# Altium schematic sheet WiFi+BT.SchDoc
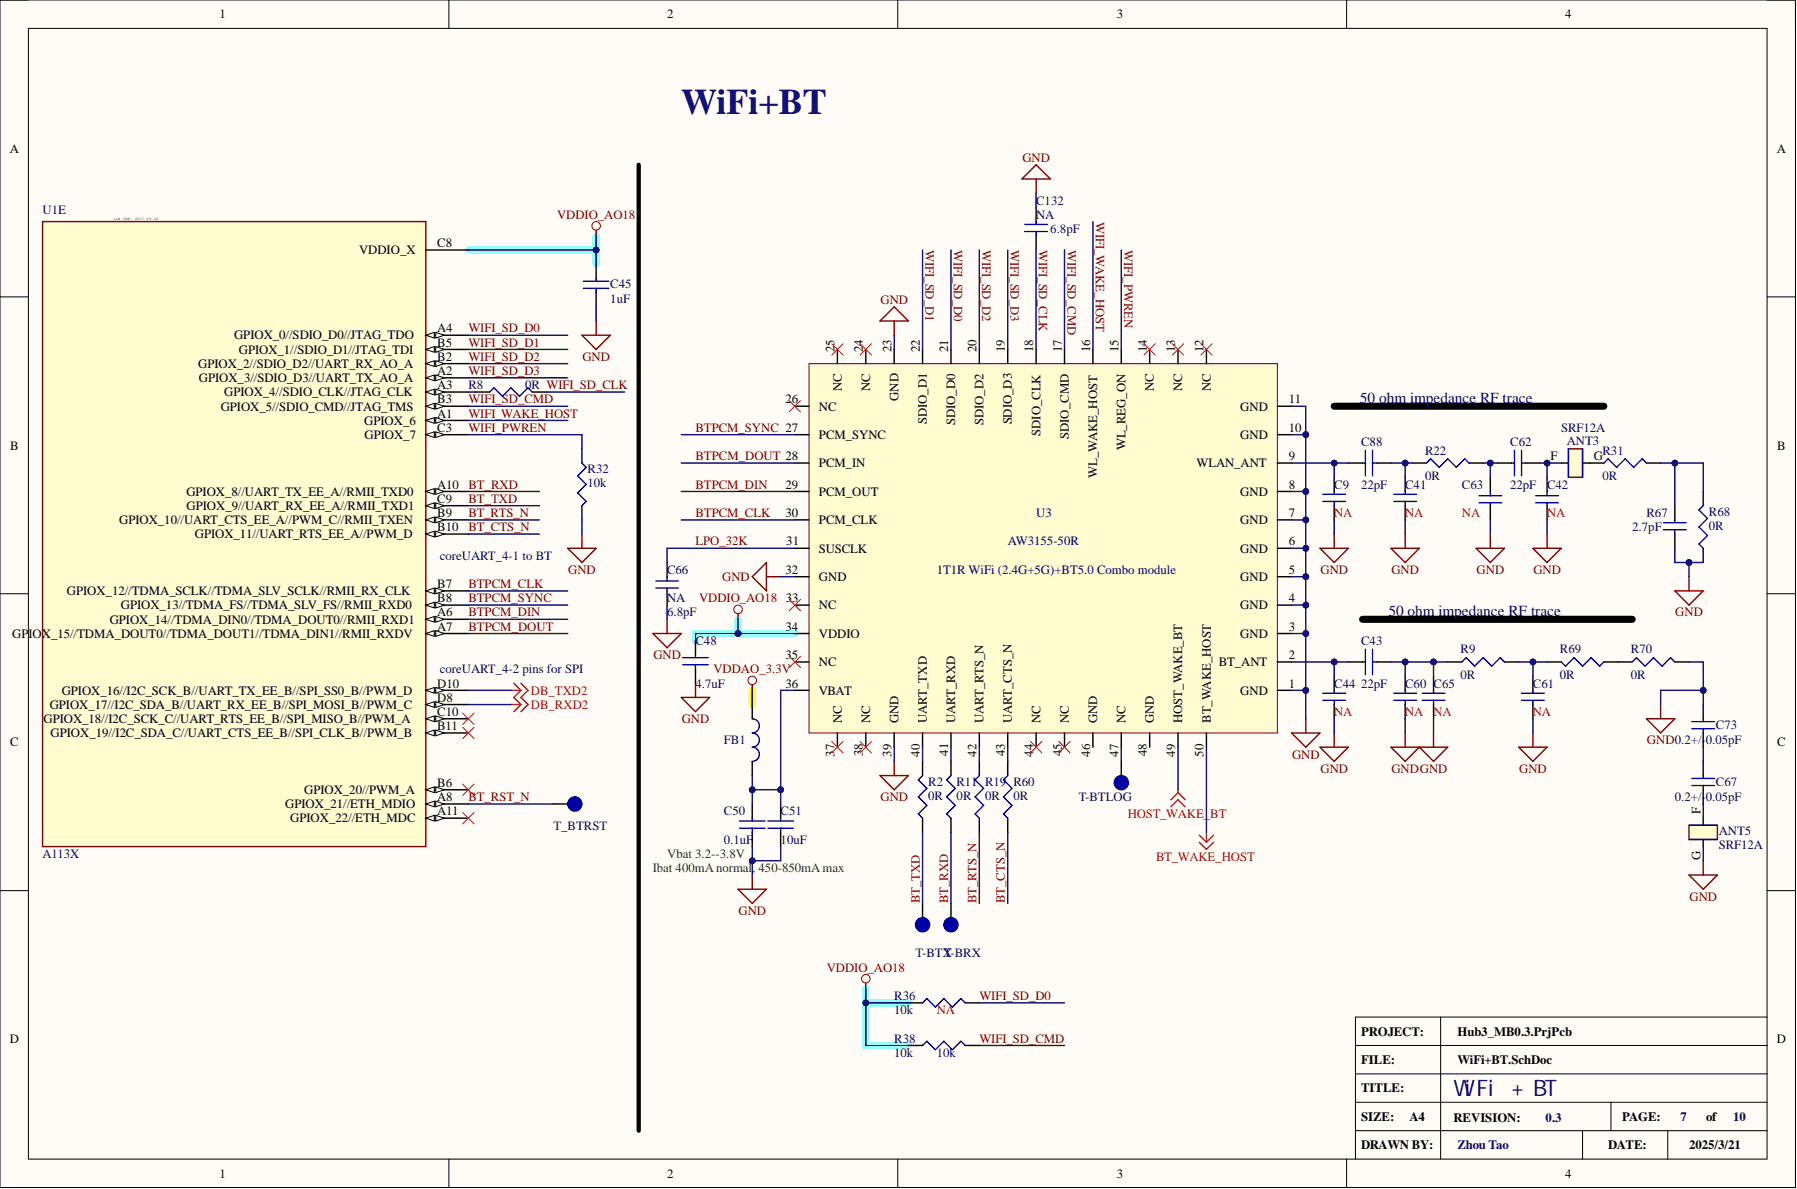
[diagram p0: WiFi+BT.SchDoc]

## Parts
ANT3  SRF12A  [ANT1608]
ANT5  SRF12A  [ANT1608]
C9  NA  [C0402]  (no BOM)
C41  NA  [C0402]  (no BOM)
C42  NA  [C0402]  (no BOM)
C43  22pF  [C0402]
C44  NA  [C0402]  (no BOM)
C45  1uF  [C0201]
C48  4.7uF  [C0603]
C50  0.1uF  [C0201]
C51  10uF  [C0603]
C60  NA  [C0402]  (no BOM)
C61  NA  [C0402]  (no BOM)
C62  22pF  [C0402]
C63  NA  [C0402]  (no BOM)
C65  NA  [C0402]  (no BOM)
C66  NA  value 6.8pF  [C0201]  (no BOM)
C67  0.2+/-0.05pF  [C0402]
C73  0.2+/-0.05pF  [C0402]
C88  22pF  [C0402]
C132  NA  value 6.8pF  [C0201]  (no BOM)
FB1  MZAS1608G251－1R1TF  [L0603]  (value not drawn)
R2  0R  [R0201]
R8  0R  [R0201]
R9  0R  [R0402]
R11  0R  [R0201]
R19  0R  [R0201]
R22  0R  [R0402]
R31  0R  [R0402]
R32  value 10k  [R0402]  (hidden comment: RES_10k_0402_1%)
R36  NA  value 10k  [R0201]  (no BOM)
R38  10k  [R0201]
R60  0R  [R0201]
R67  2.7pF  [C0402]
R68  0R  [R0402]
R69  0R  [R0402]
R70  0R  [R0402]
T-BRX  T_POINT_R_1  [TP12]  (value not drawn)  (no BOM)
T-BTLOG  T_POINT_R_1  [TP12]  (value not drawn)  (no BOM)
T-BTX  T_POINT_R_1  [TP12]  (value not drawn)  (no BOM)
T_BTRST  (no value)  [TP_D0.6mm]  (no BOM)
U1  A113X  [A113X_284]  (part E)
U3  AW3155-50R  Des: 1T1R WiFi (2.4G+5G)+BT5.0 Combo module  [AW3155-50]

## Nets
BT_WAKE_HOST: U3.50(BT_WAKE_HOST)
DB_RXD2: U1.D8(GPIOX_17//I2C_SDA_B//UART_RX_EE_B//SPI_MOSI_B//PWM_C)
DB_TXD2: U1.D10(GPIOX_16//I2C_SCK_B//UART_TX_EE_B//SPI_SS0_B//PWM_D)
GND: ANT5.G C9.2 C41.2 C42.1 C44.2 C45.2 C48.2 C50.2 C51.2 C60.2 C61.2 C63.1 C65.2 C66.1 C73.1 C132.1 R32.2 R67.2 R68.1 R70.2 U3.1(GND) U3.3(GND) U3.4(GND) U3.5(GND) U3.6(GND) U3.7(GND) U3.8(GND) U3.10(GND) U3.11(GND) U3.23(GND) U3.32(GND) U3.39(GND)
HOST_WAKE_BT: U3.49(HOST_WAKE_BT)
VDDAO_3.3V: FB1.1
VDDIO_AO18: C45.1 C48.1 R36.1 R38.1 U1.C8(VDDIO_X) U3.34(VDDIO)
BT_CTS_N: R60.1 U1.B10(GPIOX_11//UART_RTS_EE_A//PWM_D)
BT_RST_N: T_BTRST.1 U1.A8(GPIOX_21//ETH_MDIO)
BT_RTS_N: R19.1 U1.B9(GPIOX_10//UART_CTS_EE_A//PWM_C//RMII_TXEN)
BT_RXD: R11.1 T-BRX.1 U1.A10(GPIOX_8//UART_TX_EE_A//RMII_TXD0)
BT_TXD: R2.1 T-BTX.1 U1.C9(GPIOX_9//UART_RX_EE_A//RMII_TXD1)
BTPCM_CLK: U1.B7(GPIOX_12//TDMA_SCLK//TDMA_SLV_SCLK//RMII_RX_CLK) U3.30(PCM_CLK)
BTPCM_DIN: U1.A6(GPIOX_14//TDMA_DIN0//TDMA_DOUT0//RMII_RXD1) U3.29(PCM_OUT)
BTPCM_DOUT: U1.A7 U3.28(PCM_IN)
BTPCM_SYNC: U1.B8(GPIOX_13//TDMA_FS//TDMA_SLV_FS//RMII_RXD0) U3.27(PCM_SYNC)
LPO_32K: C66.2 U3.31(SUSCLK)
WIFI_PWREN: R32.1 U1.C3(GPIOX_7) U3.15(WL_REG_ON)
WIFI_SD_CLK: C132.2 R8.2 U3.18(SDIO_CLK)
WIFI_SD_CMD: R38.2 U1.B3(GPIOX_5//SDIO_CMD//JTAG_TMS) U3.17(SDIO_CMD)
WIFI_SD_D0: R36.2 U1.A4(GPIOX_0//SDIO_D0//JTAG_TDO) U3.21(SDIO_D0)
WIFI_SD_D1: U1.B5(GPIOX_1//SDIO_D1//JTAG_TDI) U3.22(SDIO_D1)
WIFI_SD_D2: U1.B2(GPIOX_2//SDIO_D2//UART_RX_AO_A) U3.20(SDIO_D2)
WIFI_SD_D3: U1.A2(GPIOX_3//SDIO_D3//UART_TX_AO_A) U3.19(SDIO_D3)
WIFI_WAKE_HOST: U1.A1(GPIOX_6) U3.16(WL_WAKE_HOST)
NetANT3_F: ANT3.F C42.2 C62.2
NetANT3_G: ANT3.G R31.1
NetANT5_F: ANT5.F C67.2
NetC9_1: C9.1 C88.1 U3.9(WLAN_ANT)
NetC41_1: C41.1 C88.2 R22.1
NetC43_1: C43.1 C44.1 U3.2(BT_ANT)
NetC43_2: C43.2 C60.1 C65.1 R9.1
NetC50_1: C50.1 C51.1 FB1.2 U3.36(VBAT)
NetC61_1: C61.1 R9.2 R69.2
NetC62_1: C62.1 C63.2 R22.2
NetC67_1: C67.1 C73.2
NetR2_2: R2.2 U3.40(UART_TXD)
NetR8_1: R8.1 U1.A3(GPIOX_4//SDIO_CLK//JTAG_CLK)
NetR11_2: R11.2 U3.41(UART_RXD)
NetR19_2: R19.2 U3.42(UART_RTS_N)
NetR31_2: R31.2 R67.1 R68.2
NetR60_2: R60.2 U3.43(UART_CTS_N)
NetR69_1: R69.1 R70.1
NetT-BTLOG_1: T-BTLOG.1 U3.47(NC)

Not connected: U1 A11(GPIOX_22//ETH_MDC) B6(GPIOX_20//PWM_A) B11(GPIOX_19//I2C_SDA_C//UART_CTS_EE_B//SPI_CLK_B//PWM_B) C10(GPIOX_18//I2C_SCK_C//UART_RTS_EE_B//SPI_MISO_B//PWM_A); U3 12(NC) 13(NC) 14(NC) 24(NC) 25(NC) 26(NC) 33(NC) 35(NC) 37(NC) 38(NC) 44(NC) 45(NC) 46(GND) 48(GND)

## Library data of the parts
ANT3 ANT5 = ANT_SMD_1608_SRF12A: Manufacturer: HanYang; Des: SMD ANT, 1608 size; Component Kind: Standard; Part Number: SRF12A; value: SRF12A; Library Name: Lib_sch_spk_V1_2020604.SchLib
C9 C41 C42 C44 C60 C61 C63 C65 = Cap_0402_NA_RF: Manufacturer: Murata; Part Number: TBD; Des: TBD.....50V, C0G, NP0 0402; value: TBD; Library Name: Lib_sch_spk_V1_2020604.SchLib
C43 C62 C88 = Cap_22pF_0402_5%_25V: Manufacturer: Murata; Part Number: GRM1555C1E220JA01D; Des: 22pF,0402,25V,5%,NP0; Component Kind: Standard; value: 22pF; Library Name: Lib_sch_Hubv3_2332.SchLib
C45 = Cap_1uF_0201_6.3V: Manufacturer: Walsin Tech; Part Number: 0201X105K6R3CT; Des: 1uF,0201,6.3V,10%,X5R; Component Kind: Standard; value: 1uF; Library Name: Lib_sch_Hubv3_2332.SchLib
C48 = Cap_4.7uF_0603_10V_lowESR: Manufacturer: TDK; Part Number: C1608X6S1A475K080AC; Des: 4.7uF,10V,X6S, 10%, 0603; Component Kind: Standard; value: 4.7uF; Library Name: Lib_sch_spk_V1_2020604.SchLib
C50 = Cap_0.1uF_0201_16V: Manufacturer: EYANG; Part Number: C0201X5R104K160NTA; Des: 0.1uF,0201,16V,X5R,10%; Component Kind: Standard; value: 0.1uF; Library Name: Lib_sch_Hubv3_2332.SchLib
C51 = Cap_10uF_0603_10%_10V: Manufacturer: Samsung; Part Number: CL10A106KP8NNNC; Des: 10uF,0603,X5R, 10%, 10V; Component Kind: Standard; value: 10uF; Library Name: Lib_sch_Hubv3_2332.SchLib
C66 C132 = Cap_6.8pF_0201_25V_±0.25pF: Manufacturer: Murata; Part Number: GRM0335C1E6R8CA01J; Des: 6.8pF,0201,25V,C0G/NP0; Library Name: Lib_sch_spk_V0_181010.SchLib
C67 C73 = Cap_0402_0.2pF_HYANT_ViiYong: Part Number: V0R2A0402HQC500NBT; Des: 0.2pF, +/-0.05pF, 0402, HQC; Component Kind: Standard; Manufacturer: ViiYong; value: 0.2+/-0.05pF; Library Name: Lib_sch_Hubv3_2332.SchLib
FB1 = FB_22@100MHz_0603: Manufacturer: Sunlord; Des: 250 Ohm @100MHz +/-25%,1.1A,0.19DCR 0603; Component Kind: Standard; Part Number: MZAS1608G251－1R1TF; value: MZAS1608G251－1R1TF; Library Name: Lib_sch_spk_V0_190722.SchLib
R2 R8 R11 R19 R60 = RES_0R_0201: Manufacturer: Yageo; part number: RC0201JR-070RL; Des: 0R,0201,1/20w; Component Kind: Standard; value: 0R; Library Name: Lib_sch_Hubv3_2332.SchLib
R9 R22 R31 R68-R70 = RES_0R_0402_5%: Manufacturer: Yageo; part number: RC0402JR-070RL; Des: 0R,0402,5%,1/16w; Component Kind: Standard; value: 0R; Library Name: Lib_sch_Hubv3_2332.SchLib
R32 = RES_10k_0402_1%: Manufacturer: Yageo; part number: RC0402FR-0710KL; Des: 10k,0402,1%; Component Kind: Standard; Library Name: Lib_sch_spk_V0_180613.SchLib
R36 R38 = RES_10k_0201_1%: Manufacturer: Yageo; part number: RC0201FR-0710KL; Des: 10k,0201,1%; Library Name: Lib_sch_spk_V1_2020604.SchLib
  R38: Component Kind: Standard; value: 10k
R67 = Cap_0402_2.7pF_HYANT: Manufacturer: Murata; Part Number: GRM1555C1H2R7BA01D; Des: 2.7pF+/-0.1pF, 50V, C0G, 0402; Component Kind: Standard; value: 2.7pF; Library Name: Lib_sch_Hubv3_2332.SchLib
T-BRX T-BTLOG T-BTX = T_POINT_R_1: Des: circle test pad (Dia=0.3mm ); Library Name: Lib_sch_Hubv3_2332.SchLib
T_BTRST = T_POINT_D0.6mm: Des: circle test pad (Dia=0.6mm ); Library Name: Lib_sch_spk_V0_181010.SchLib
U1 = A113X_20170516_V17: Manufacturer: AmLogic; Des: A113X, BGA,V17; Component Kind: Standard; Part Number: A113X; value: A113X; Library Name: Lib_sch_Hubv3_2332.SchLib
U3 = AW3155-50R: Manufacturer: ITON; Component Kind: Standard; Part Number: AW3155-50R; value: AW3155-50R; Library Name: Lib_sch_spk_V1_2020604.SchLib
